# Altium schematic sheet BC.SchDoc
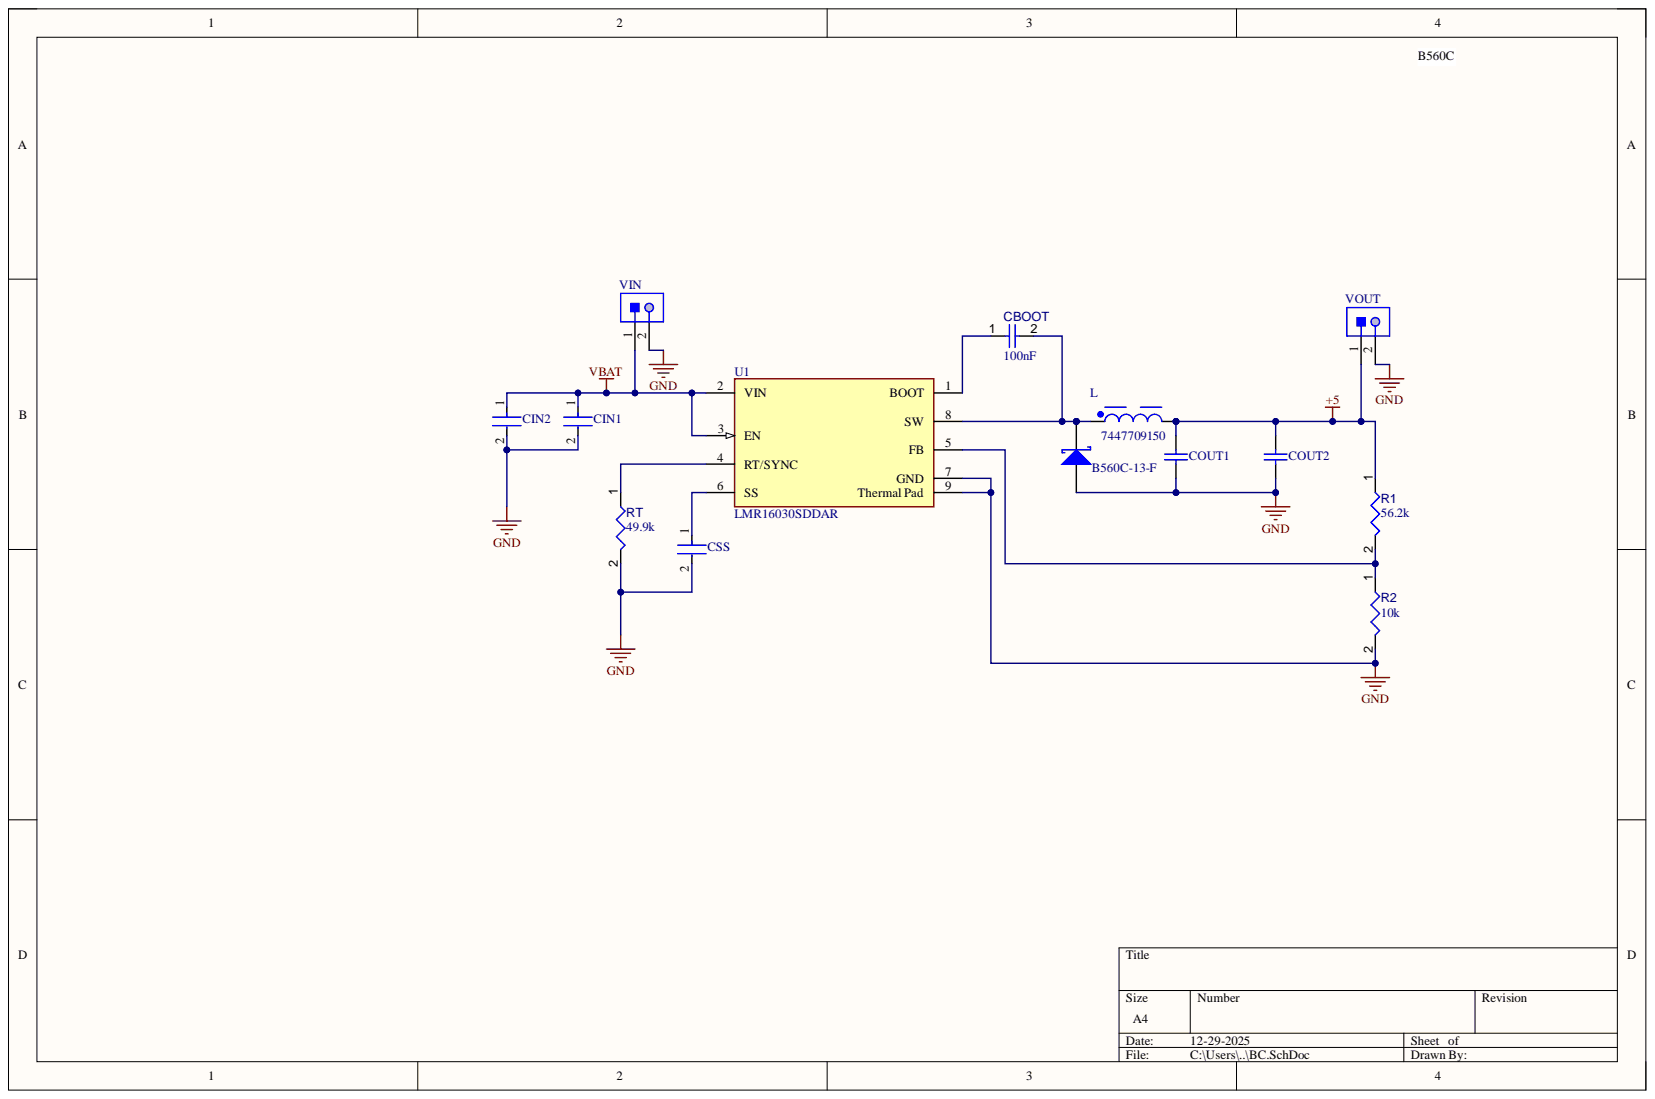
[diagram p0: BC.SchDoc]

## Parts
CBOOT  value 100nF  [CAPC1608X90X40NL10T20]  (hidden comment: Capacitor 100 nF +/-10% 50 V 0603)
CIN1  GCJ32DC72A475ME01L  [FP-GCJ32D-0_4-MFG]  (value not drawn)
CIN2  GCJ32DC72A475ME01L  [FP-GCJ32D-0_4-MFG]  (value not drawn)
COUT1  GRM32ER61C476ME15L  [MURA-1210_H_2.7_M]  (value not drawn)
COUT2  GRM32ER61C476ME15L  [MURA-1210_H_2.7_M]  (value not drawn)
CSS  CC0603KRX7R9BB223  [FP-CC0603-DA-MFG]  (value not drawn)
D  B560C-13-F  [DIOD-B5X0C-2_M]
L  7447709150  [WE-PD_1210]
R1  value 56.2k  [RESC1608X55X25ML10T15]  (hidden comment: Resistor 10k +/-1% 0603 100 mW)
R2  value 10k  [RESC1608X55X25ML10T15]  (hidden comment: Resistor 10k +/-1% 0603 100 mW)
RT  value 49.9k  [RESC1608X55X25ML10T15]  (hidden comment: Resistor 10k +/-1% 0603 100 mW)
U1  LMR16030SDDAR  [FP-DDA0008E-IPC_A]
VIN  S2B-PH-K-S(LF)(SN)  [JST-S2B-PH-K-S_V]  (value not drawn)
VOUT  S2B-PH-K-S(LF)(SN)  [JST-S2B-PH-K-S_V]  (value not drawn)

## Nets
+5: COUT1.2 COUT2.2 L.2 R1.1 VOUT.1
GND: CIN1.2 CIN2.2 COUT1.1 COUT2.1 CSS.2 D.2 R2.2 RT.2 U1.7(GND) U1.9(Thermal_Pad) VIN.2 VOUT.2
VBAT: CIN1.1 CIN2.1 U1.2(VIN) U1.3(EN) VIN.1
NetCBOOT_1: CBOOT.1 U1.1(BOOT)
NetCBOOT_2: CBOOT.2 D.1 L.1 U1.8(SW)
NetCSS_1: CSS.1 U1.6(SS)
NetR1_2: R1.2 R2.1 U1.5(FB)
NetRT_1: RT.1 U1.4(RT/SYNC)

## Library data of the parts
CBOOT = CMP-001-00017-6: Chip Capacitor, 100 nF, +/- 10%, 50 V, 0603 (1608 Metric); Capacitor Type: Ceramic; Voltage Rating: 50V; Case/Package: 0603; Component Kind: Standard; Max Operating Temperature: 125°C; Min Operating Temperature: -55°C; Mounting Technology: SM; Pins: 2; RoHS Compliant: True; Tolerance: 10%; Library Name: Americas - McMaster University - McMaster Robosub
CIN1 CIN2 = CMP-06035-005025-1: 4.7uF ±20% 100V Ceramic Capacitor X7S 1210 (3225 Metric); Component Kind: Standard; Library Name: Altium Content Vault
COUT1 COUT2 = CMP-2000-05260-1: CAP, Ceramic, 47 uF, 20%, 16 V, 1210, RoHS, Tape and Reel; Manufacturer: Murata; Brand: MurataElectronics; Capacitance: 47uF; Case Height: 2.5mm; Case Length: 3.2mm; Dielectric: X5R; Voltage Rating DC: 16V; Case Code - in: 1210; Case Code - mm: 3225; Case Width: 2.5mm; Component Kind: Standard; Datasheet URL: https://datasheet.ciiva.com/3777/2723grm32er61c476me1523-3777296.pdf; Datasheet Version: Aug-14; Factory Pack Quantity: 1000; Library Name: Altium Content Vault; Manufacturer URL: http://www.murata.com/; Maximum Operating Temperature: +85C; Minimum Operating Temperature: -55C; Package / Case: 1210(3225metric); Package Description: 2-Pin Surface Mount Device, Body 3.2 x 2.5 mm; Package Reference: 1210; Packaging: Reel; Product: GeneralTypeMLCCs; Product Category: MultilayerCeramicCapacitorsMLCC-SMD/SMT; RoHS: Details; Series: GRM; Termination Style: SMD/SMT; Tolerance: 20%; Type: GeneralPurposeMLCC
CSS = CMP-2100-03602-3: CAP CER 0.022UF 50V X7R 0603; Capacitance: 22nF; Dielectric Material: Ceramic; Insulation Resistance: 100GR; Tolerance: 10%; Case Code (Imperial): 0603; Case Code (Metric): 1608; Case/Package: 0603; Component Kind: Standard; Depth: 0.8mm; Dielectric: X7R; Halogen Free: Halogen Free; Height: 0.8mm; Lead Free: Lead Free; Length: 1.6mm; Library Name: Altium Content Vault; Max Operating Temperature: 125°C; Min Operating Temperature: -55°C; Mount: Surface Mount; Number of Pins: 2; Package Quantity: 1; Packaging: Tape and Reel; Radiation Hardening: No; REACH SVHC: No SVHC; Resistor Type: Ceramic; RoHS Compliant: Yes; Series: CC; Termination: SMD/SMT; Thickness: 0.035inch; Voltage: 50V; Voltage Rating: 50V; Voltage Rating (DC): 50V; Width: 0.031inch
D = CMP-2000-05098-2: Schottky Barrier Rectifier, 5 A, 60 V, -55 to 125 degC, 2-Pin SMD, RoHS, Tape and Reel; Brand: DiodesIncorporated; Configuration: Single; If - Forward Current: 5A; Ifsm - Forward Surge Current: 100A; Ir - Reverse Current: 500uA; Unit Weight: 0.007408oz; Vf - Forward Voltage: 0.7V; Vrrm - Repetitive Reverse Voltage: 60V; Component Kind: Standard; Datasheet URL: https://datasheet.ciiva.com/27052/1538961-27052355.pdf; Datasheet Version: Rev. 7-2, 06/2007; Factory Pack Quantity: 3000; Height: 2.5mm; Length: 7.11mm; Library Name: Altium Content Vault; Manufacturer: DiodesIncorporated; Manufacturer URL: http://www.diodes.com/; Maximum Operating Temperature: +125C; Minimum Operating Temperature: -55C; Mounting Style: SMD/SMT; Operating Temperature Range: -55Cto+125C; Package / Case: SMC; Packaging: Reel; Product: SchottkyDiodes; Product Category: SchottkyDiodes&Rectifiers; RoHS: True; Series: B560; Technology: Si; Termination Style: SMD/SMT; Type: SchottkyBarrierRectifier; Width: 6.22mm
L = CMP-0227-00106-3: Power Choke Shielded Wirewound 15uH 20% 1KHz Ferrite 6.5A 26mOhm DCR T/R; DC Resistance (DCR): 21mΩ; Inductance: 15µH; Saturation Current: 8A; Self Resonant Frequency: 17MHz; Series Resistance: 26mΩ; Ambient Temperature Range High: 85°C; Ambient Temperature Range Low: -40°C; Case Code (Imperial): 1210; Case Code (Metric): 3225; Case/Package: Nonstandard; Component Kind: Standard; Composition: Wirewound; Core Material: Ferrite; Current Rating: 6.5A; Depth: 12mm; Height: 10mm; Height - Seated (Max): 10.0076mm; Inductance Tolerance: 20%; Lead Free: Lead Free; Lead Pitch: 7mm; Length: 12mm; Library Name: Altium Content Vault; Max DC Current: 6.5A; Max Operating Temperature: 125°C; Min Operating Temperature: -40°C; Mount: Surface Mount; Number of Pins: 2; RMS Current (Irms): 6.5A; RoHS: Compliant; Shielding: Shielded; Termination: SMD/SMT; Test Frequency: 1kHz; Tolerance: 20%; Weight: 9.071847g; Width: 12mm
R1 R2 RT = CMP-009-00017-5: Chip Resistor, 10 KOhm, +/- 1%, 01 W, -55 to 155 degC, 0603 (1608 Metric); Power: 100mW; Voltage Rating: 50V; Case/Package: 0603; Component Kind: Standard; Max Operating Temperature: 155°C; Min Operating Temperature: -55°C; Mounting Technology: SM; Pins: 2; RoHS Compliant: True; Tolerance: 1%; Library Name: Americas - McMaster University - McMaster Robosub
U1 = CMP-04918-000376-1: IC REG BUCK ADJUSTABLE 3A 8SOPWR; Quiescent Current: 40uA; Component Kind: Standard; Lead Free: Lead Free; Library Name: Altium Content Vault; Max Operating Temperature: 125°C; Min Operating Temperature: -40°C; Number of Pins: 8; RoHS Compliant: Yes
VIN VOUT = CMP-002-00030-2: Male Header, Pitch 2 mm, 1 x 2 Position, Height 4.8 mm, Tail Length 3.4 mm, -25 to 85 degC, RoHS, Bulk; Manufacturer: Japan Solderless Terminals; Case/Package: S2B-PH-K-S; Component Kind: Standard; Connector Type: Header; Datasheet URL: http://www.jst-mfg.com/product/pdf/eng/ePH.pdf; Datasheet Version: Dec-12; Gender: Male; Max Operating Temperature: 85°C; Min Operating Temperature: -25°C; Mounting Technology: SM; Package Description: Male Header, Pitch 2 mm, 1 x 2 Position, Height 4.8 mm, Tail Length 3.4 mm; Package Reference: S2B-PH-K-S; RoHS Compliant: Unknown; Library Name: Americas - McMaster University - McMaster Robosub
